# Altium schematic sheet P02_WiFi 1 of 2.SchDoc
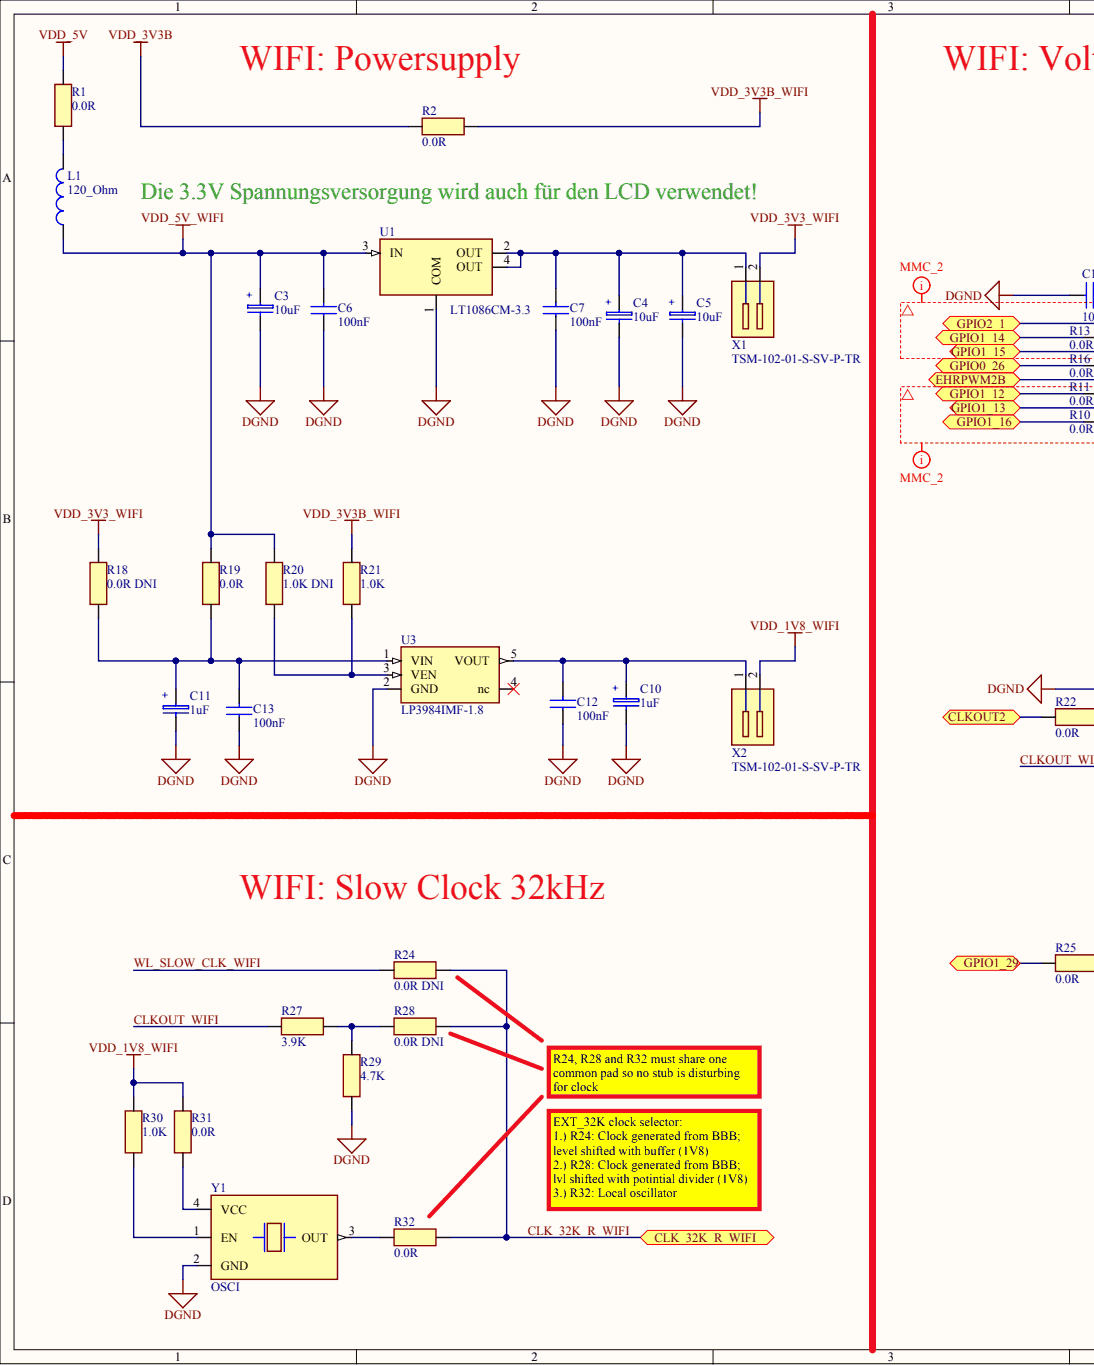
[diagram p0: P02_WiFi 1 of 2.SchDoc, left]
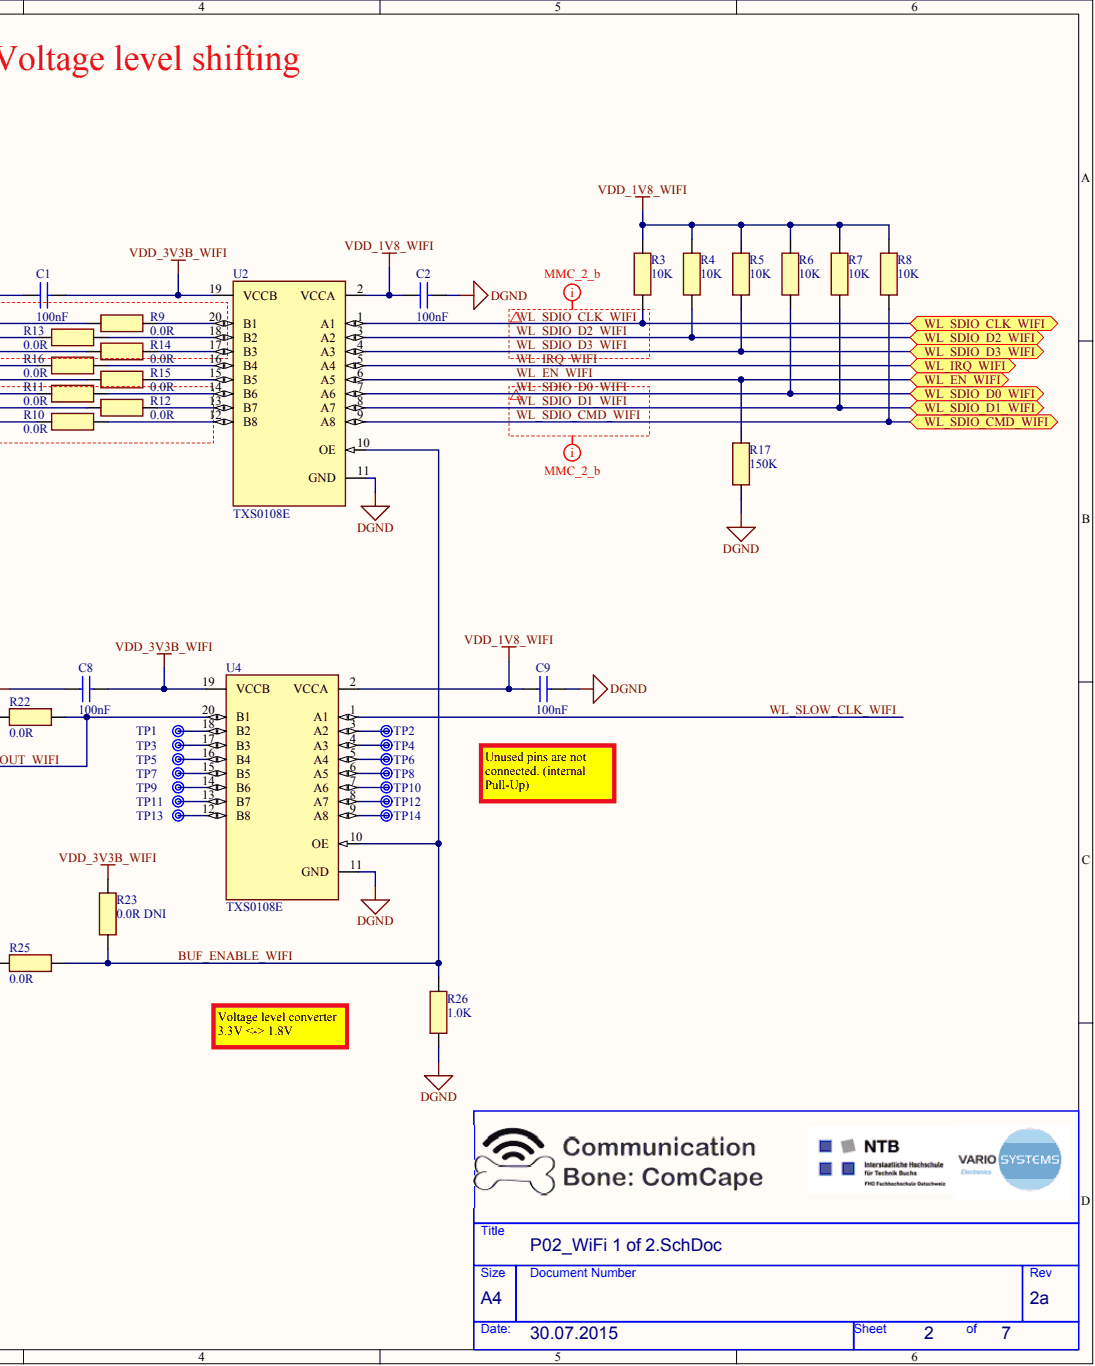
[diagram p1: P02_WiFi 1 of 2.SchDoc, right]

## Parts
C1  100nF  [SM/C_0603]
C2  100nF  [SM/C_0603]
C3  10uF  [SM/CP_3528]
C4  10uF  [SM/CP_3528]
C5  10uF  [SM/CP_3528]
C6  100nF  [SM/C_0603]
C7  100nF  [SM/C_0603]
C8  100nF  [SM/C_0603]
C9  100nF  [SM/C_0603]
C10  1uF  [SM/CP_3216]
C11  1uF  [SM/CP_3216]
C12  100nF  [SM/C_0603]
C13  100nF  [SM/C_0603]
L1  120_Ohm  [SM/L_1206]
R1  0.0R  [SM/R_0603]
R2  0.0R  [SM/R_0603]
R3  10K  [SM/R_0603]
R4  10K  [SM/R_0603]
R5  10K  [SM/R_0603]
R6  10K  [SM/R_0603]
R7  10K  [SM/R_0603]
R8  10K  [SM/R_0603]
R9  0.0R  [SM/R_0603]
R10  0.0R  [SM/R_0603]
R11  0.0R  [SM/R_0603]
R12  0.0R  [SM/R_0603]
R13  0.0R  [SM/R_0603]
R14  0.0R  [SM/R_0603]
R15  0.0R  [SM/R_0603]
R16  0.0R  [SM/R_0603]
R17  150K  [SM/R_0603]
R18  0.0R DNI  value 0.0R  [SM/R_0603]
R19  0.0R  [SM/R_0603]
R20  1.0K DNI  value 1.0K  [SM/R_0603]
R21  1.0K  [SM/R_0603]
R22  0.0R  [SM/R_0603]
R23  0.0R DNI  value 0.0R  [SM/R_0603]
R24  0.0R DNI  value 0.0R  [SM/R_0603]
R25  0.0R  [SM/R_0603]
R26  1.0K  [SM/R_0603]
R27  3.9K  [SM/R_0603]
R28  0.0R DNI  value 0.0R  [SM/R_0603]
R29  4.7K  [SM/R_0603]
R30  1.0K  [SM/R_0603]
R31  0.0R  [SM/R_0603]
R32  0.0R  [SM/R_0603]
TP1  Testpoint mit Bohrung  [TP_mit_0.7mm_Bohrung]  (value not drawn)  (no BOM)
TP2  Testpoint mit Bohrung  [TP_mit_0.7mm_Bohrung]  (value not drawn)  (no BOM)
TP3  Testpoint mit Bohrung  [TP_mit_0.7mm_Bohrung]  (value not drawn)  (no BOM)
TP4  Testpoint mit Bohrung  [TP_mit_0.7mm_Bohrung]  (value not drawn)  (no BOM)
TP5  Testpoint mit Bohrung  [TP_mit_0.7mm_Bohrung]  (value not drawn)  (no BOM)
TP6  Testpoint mit Bohrung  [TP_mit_0.7mm_Bohrung]  (value not drawn)  (no BOM)
TP7  Testpoint mit Bohrung  [TP_mit_0.7mm_Bohrung]  (value not drawn)  (no BOM)
TP8  Testpoint mit Bohrung  [TP_mit_0.7mm_Bohrung]  (value not drawn)  (no BOM)
TP9  Testpoint mit Bohrung  [TP_mit_0.7mm_Bohrung]  (value not drawn)  (no BOM)
TP10  Testpoint mit Bohrung  [TP_mit_0.7mm_Bohrung]  (value not drawn)  (no BOM)
TP11  Testpoint mit Bohrung  [TP_mit_0.7mm_Bohrung]  (value not drawn)  (no BOM)
TP12  Testpoint mit Bohrung  [TP_mit_0.7mm_Bohrung]  (value not drawn)  (no BOM)
TP13  Testpoint mit Bohrung  [TP_mit_0.7mm_Bohrung]  (value not drawn)  (no BOM)
TP14  Testpoint mit Bohrung  [TP_mit_0.7mm_Bohrung]  (value not drawn)  (no BOM)
U1  LT1086CM-3.3  [SM/DDPAK]
U2  TXS0108E  [TSSOP.65M/20/WG.252/L.255]
U3  LP3984IMF-1.8  [SM/SOT23_5]
U4  TXS0108E  [TSSOP.65M/20/WG.252/L.255]
X1  TSM-102-01-S-SV-P-TR  [HEADER_2_PIN]
X2  TSM-102-01-S-SV-P-TR  [HEADER_2_PIN]
Y1  OSCI  [OSCI_SG310]

## Ports
CLK_32K_R_WIFI, CLKOUT2, EHRPWM2B, GPIO0_26, GPIO1_12, GPIO1_13, GPIO1_14, GPIO1_15, GPIO1_16, GPIO1_29, GPIO2_1, WL_EN_WIFI, WL_IRQ_WIFI, WL_SDIO_CLK_WIFI, WL_SDIO_CMD_WIFI, WL_SDIO_D0_WIFI, WL_SDIO_D1_WIFI, WL_SDIO_D2_WIFI, WL_SDIO_D3_WIFI

## Nets
DGND: C1.1 C2.2 C3.N C4.N C5.N C6.1 C7.1 C8.1 C9.2 C10.N C11.N C12.1 C13.1 R17.2 R26.2 R29.2 U1.1(COM) U2.11(GND) U3.2(GND) U4.11(GND) Y1.2(GND)
VDD_1V8_WIFI: C2.1 C9.1 R3.1 R4.1 R5.1 R6.1 R7.1 R8.1 R30.1 R31.2 U2.2(VCCA) U4.2(VCCA) X2.2
VDD_3V3_WIFI: R18.1 X1.2
VDD_3V3B: R2.2
VDD_3V3B_WIFI: C1.2 C8.2 R2.1 R21.1 R23.1 U2.19(VCCB) U4.19(VCCB)
VDD_5V: R1.1
VDD_5V_WIFI: C3.P C6.2 L1.1 R19.1 R20.1 U1.3(IN)
BUF_ENABLE_WIFI: R23.2 R25.2 R26.1 U2.10(OE) U4.10(OE)
CLK_32K_R_WIFI: R24.2 R28.2 R32.1 ; port CLK_32K_R_WIFI
CLKOUT2: R22.1 ; port CLKOUT2
CLKOUT_WIFI: R22.2 R27.1 U4.20(B1)
EHRPWM2B: R15.1 ; port EHRPWM2B
GPIO0_26: R16.1 ; port GPIO0_26
GPIO1_12: R11.1 ; port GPIO1_12
GPIO1_13: R12.1 ; port GPIO1_13
GPIO1_14: R13.1 ; port GPIO1_14
GPIO1_15: R14.1 ; port GPIO1_15
GPIO1_16: R10.1 ; port GPIO1_16
GPIO1_29: R25.1 ; port GPIO1_29
GPIO2_1: R9.2 ; port GPIO2_1
WL_EN_WIFI: R17.1 U2.6(A5) ; port WL_EN_WIFI
WL_IRQ_WIFI: U2.5(A4) ; port WL_IRQ_WIFI
WL_SDIO_CLK_WIFI: R3.2 U2.1(A1) ; port WL_SDIO_CLK_WIFI
WL_SDIO_CMD_WIFI: R8.2 U2.9(A8) ; port WL_SDIO_CMD_WIFI
WL_SDIO_D0_WIFI: R6.2 U2.7(A6) ; port WL_SDIO_D0_WIFI
WL_SDIO_D1_WIFI: R7.2 U2.8(A7) ; port WL_SDIO_D1_WIFI
WL_SDIO_D2_WIFI: R4.2 U2.3(A2) ; port WL_SDIO_D2_WIFI
WL_SDIO_D3_WIFI: R5.2 U2.4(A3) ; port WL_SDIO_D3_WIFI
WL_SLOW_CLK_WIFI: R24.1 U4.1(A1)
NetC4_P: C4.P C5.P C7.2 U1.2(OUT) U1.4(OUT) X1.1
NetC10_P: C10.P C12.2 U3.5(VOUT) X2.1
NetC11_P: C11.P C13.2 R18.2 R19.2 U3.1(VIN)
NetL1_2: L1.2 R1.2
NetR9_1: R9.1 U2.20(B1)
NetR10_2: R10.2 U2.12(B8)
NetR11_2: R11.2 U2.14(B6)
NetR12_2: R12.2 U2.13(B7)
NetR13_2: R13.2 U2.18(B2)
NetR14_2: R14.2 U2.17(B3)
NetR15_2: R15.2 U2.15(B5)
NetR16_2: R16.2 U2.16(B4)
NetR20_2: R20.2 R21.2 U3.3(VEN)
NetR27_2: R27.2 R28.1 R29.1
NetR30_2: R30.2 Y1.1(EN)
NetR31_1: R31.1 Y1.4(VCC)
NetR32_2: R32.2 Y1.3(OUT)
NetTP1_1: TP1.1 U4.18(B2)
NetTP2_1: TP2.1 U4.3(A2)
NetTP3_1: TP3.1 U4.17(B3)
NetTP4_1: TP4.1 U4.4(A3)
NetTP5_1: TP5.1 U4.16(B4)
NetTP6_1: TP6.1 U4.5(A4)
NetTP7_1: TP7.1 U4.15(B5)
NetTP8_1: TP8.1 U4.6(A5)
NetTP9_1: TP9.1 U4.14(B6)
NetTP10_1: TP10.1 U4.7(A6)
NetTP11_1: TP11.1 U4.13(B7)
NetTP12_1: TP12.1 U4.8(A7)
NetTP13_1: TP13.1 U4.12(B8)
NetTP14_1: TP14.1 U4.9(A8)
N00005:
N00006:
N00007:
N00008:

Not connected: U3 4(nc)

## Library data of the parts
C1 C2 C6-C9 C12 C13 = (no symbol name): SC CAP __0603 100n00 _16V ___10% X7R ___; Manufacturer: Generic Component; Part Number: 209336; Cust: Y; HTNr: take notice of key data; Tolerance: 10%; Voltage: 16V; Component Kind: Standard; Part Type: CAP-SMD\Keramik\0603; PCB Footprint: SM/C_0603; Std: STD; Supplier 1: Digi-Key; Supplier Part Number 1: 399-1096-1-ND; Value: 100nF; Library Name: ComCape.SchLib
C3-C5 = SC CAP __3528 _10u00 _10V ___20% TAN ___: Manufacturer: Generic Component; Part Number: 208069; Cust: Y; HTNr: take notice of key data; Tolerance: 20%; Voltage: 10V; Component Kind: Standard; Description: SC CAP __3528 _10u00 _10V ___20% TAN ___; Part Type: CAP-SMD\Tantal; Std: STD; Supplier 1: Digi-Key; Supplier Part Number 1: 399-3707-1-ND; Library Name: ComCape.SchLib
C10 C11 = SC CAP __3216 __1u00 _16V ___10% TAN ___: Manufacturer: Kemet; Part Number: 227555; Cust: Y; HTNr: T491A105K016AT; Tolerance: 10%; Voltage: 16V; Component Kind: Standard; Description: SC CAP __3216 __1u00 _16V ___10% TAN ___; Part Type: CAP-SMD\Tantal; Supplier 1: Digi-Key; Supplier Part Number 1: 399-3678-1-ND; Datasheet: S:\Datenb\DS-221192-000A.pdf; Library Name: ComCape.SchLib
L1 = SC FIL _____1206 BLM31PG121SN1* ____ FER: Manufacturer: Murata; Part Number: 244155; Cust: Y; Component Kind: Standard; Description: SC FIL _____1206 BLM31PG121SN1* ____ FER; HTNr: BLM31PG121SN1*; Part Type: IND-Inductor\SMD; Std: STD; Supplier 1: Mouser; Supplier Part Number 1: 81-BLM31PG121SN1L; Datasheet: S:\Datenb\DS-227431-000B.pdf; Library Name: ComCape.SchLib
R1 R2 R9-R16 R18 R19 R22-R25 R28 R31 R32 = SC RES __0603 __0R00 __0W1 _____ T100 __: Manufacturer: Generic Component; Part Number: 206748; Cust: Y; HTNr: take notice of key data; Power: 0.1W; Std: STD SET; Tolerance: 1%; Component Kind: Standard; Description: SC RES __0603 __0R00 __0W1 _____ T100 __; Part Type: RES-SMD\0603; PCB Footprint: SM/R_0603; Supplier 1: Digi-Key; Supplier Part Number 1: 1276-3510-1-ND; Library Name: ComCape.SchLib
  R1 R2 R9-R16 R19 R22 R25 R31 R32: Value: 0.0R
R3-R8 = SC RES __0603 _10K00 __0W1 ___1% T100 __: Manufacturer: Generic Component; Part Number: 200127; Cust: Y; HTNr: take notice of key data; Power: 0.1W; Std: STD SET; Tolerance: 1%; Component Kind: Standard; Description: SC RES __0603 _10K00 __0W1 ___1% T100 __; Part Type: RES-SMD\0603; PCB Footprint: SM/R_0603; Supplier 1: Digi-Key; Supplier Part Number 1: 1276-3485-1-ND; Value: 10K; Library Name: ComCape.SchLib
R17 = SC RES __0603 150K00 __0W1 ___1% T100 __: Manufacturer: Generic Component; Part Number: 205705; Cust: Y; HTNr: take notice of key data; Power: 0.1W; Std: STD SET; Tolerance: 1%; Component Kind: Standard; Description: SC RES __0603 150K00 __0W1 ___1% T100 __; Part Type: RES-SMD\0603; PCB Footprint: SM/R_0603; Supplier 1: Digi-Key; Supplier Part Number 1: 1276-4853-1-ND; Value: 150K; Library Name: ComCape.SchLib
R20 R21 R26 R30 = SC RES __0603 __1K00 __0W1 ___1% T100 __: Manufacturer: Generic Component; Part Number: 200139; Cust: Y; HTNr: take notice of key data; Power: 0.1W; Std: STD SET; Tolerance: 1%; Component Kind: Standard; Description: SC RES __0603 __1K00 __0W1 ___1% T100 __; Part Type: RES-SMD\0603; PCB Footprint: SM/R_0603; Supplier 1: Digi-Key; Supplier Part Number 1: 1276-3484-1-ND; Library Name: ComCape.SchLib
  R21 R26 R30: Value: 1.0K
R27 = SC RES __0603 __3K90 __0W1 ___1% T100 __: Manufacturer: Generic Component; Part Number: 221128; Cust: Y; HTNr: take notice of key data; Power: 0.1W; Std: STD SET; Tolerance: 1%; Component Kind: Standard; Description: SC RES __0603 __3K90 __0W1 ___1% T100 __; Part Type: RES-SMD\0603; PCB Footprint: SM/R_0603; Supplier 1: Digi-Key; Supplier Part Number 1: 1276-4703-1-ND; Value: 3.9K; Library Name: ComCape.SchLib
R29 = SC RES __0603 __4K70 __0W1 ___1% T100 __: Manufacturer: Generic Component; Part Number: 202773; Cust: Y; HTNr: take notice of key data; Power: 0.1W; Std: STD SET; Tolerance: 1%; Component Kind: Standard; Description: SC RES __0603 __4K70 __0W1 ___1% T100 __; Part Type: RES-SMD\0603; PCB Footprint: SM/R_0603; Supplier 1: Digi-Key; Supplier Part Number 1: 1276-3502-1-ND; Value: 4.7K; Library Name: ComCape.SchLib
TP1-TP14 = Testpoint mit Bohrung: TP_0.7mm_THRU; Library Name: ComCape.SchLib
U1 = S_ IC_ ____D2PAK LT1086CM-3.3________ __: Manufacturer: Linear Technology; Part Number: 203098; Cust: Y; Component Kind: Standard; Description: S_ IC_ ____D2PAK LT1086CM-3.3________ __; HTNr: LT1086CM-3.3; Part Type: IC-Analog\Spannungsversorgung; Supplier 1: Digi-Key; Supplier Part Number 1: LT1086CM-3.3#PBF-ND; Datasheet: S:\Datenb\DS-203098-000A.pdf; Library Name: ComCape.SchLib
U2 U4 = TXS0108E: IC 8BIT NON-INV TRANSLTR 20TSSOP; Manufacturer: Texas Instruments; HTNr: TXS0108EPWR; Component Kind: Standard; Datasheet: http://www.ti.com/lit/ds/symlink/txs0108e.pdf; DigiKey Part Number: 296-23011-2-ND; Supplier 1: Digi-Key; Library Name: ComCape.SchLib
  U2: Supplier Part Number 1: 296-23011-1-ND
  U4: Supplier Part Number 1: 296-23011-6-ND
U3 = S_ IC_ __SOT23-5 LP3984IMF-1.8/NOPB__ __: Manufacturer: National Semiconductor; Part Number: 244166; Cust: Y; Component Kind: Standard; Description: S_ IC_ __SOT23-5 LP3984IMF-1.8/NOPB__ __; HTNr: LP3984IMF-1.8/NOPB; Part Type: IC-Analog\Spannungsversorgung; Std: STD; Supplier 1: Digi-Key; Supplier Part Number 1: 296-39058-1-ND; Datasheet: S:\Datenb\DS-244166-000A.pdf; Library Name: ComCape.SchLib
X1 X2 = (no symbol name): S_ PHE M ST ____ ___2P _1RO AU R2.54 ___; Manufacturer: Samtec; Part Number: 2007330; Rating: 2.54mm; Component Kind: Standard; HTNr: TSM-102-01-S-SV-P-TR; Part Type: MISC-Connectors\Pin-Header; Supplier 1: Mouser; Supplier Part Number 1: 649-54201-G0802ALF; Datasheet: S:\Datenb\DS-226625-000C.pdf; Library Name: ComCape.SchLib
Y1 = OSCI_4: Manufacturer: CTS; HTNr: TC32M5I32K7680; Component Kind: Standard; Datasheet: http://media.digikey.com/pdf/Data%20Sheets/CTS%20Corporation%20PDFs/Model%20TC32.pdf; DigiKey Part Number: CTX1181CT-ND; Supplier 1: Digi-Key; Supplier Part Number 1: CTX1181DKR-ND; Library Name: VS-QUARZ-000A.SchLib
